# Altium schematic sheet STC3115_without_SensorHub-EvalBoard.schdoc
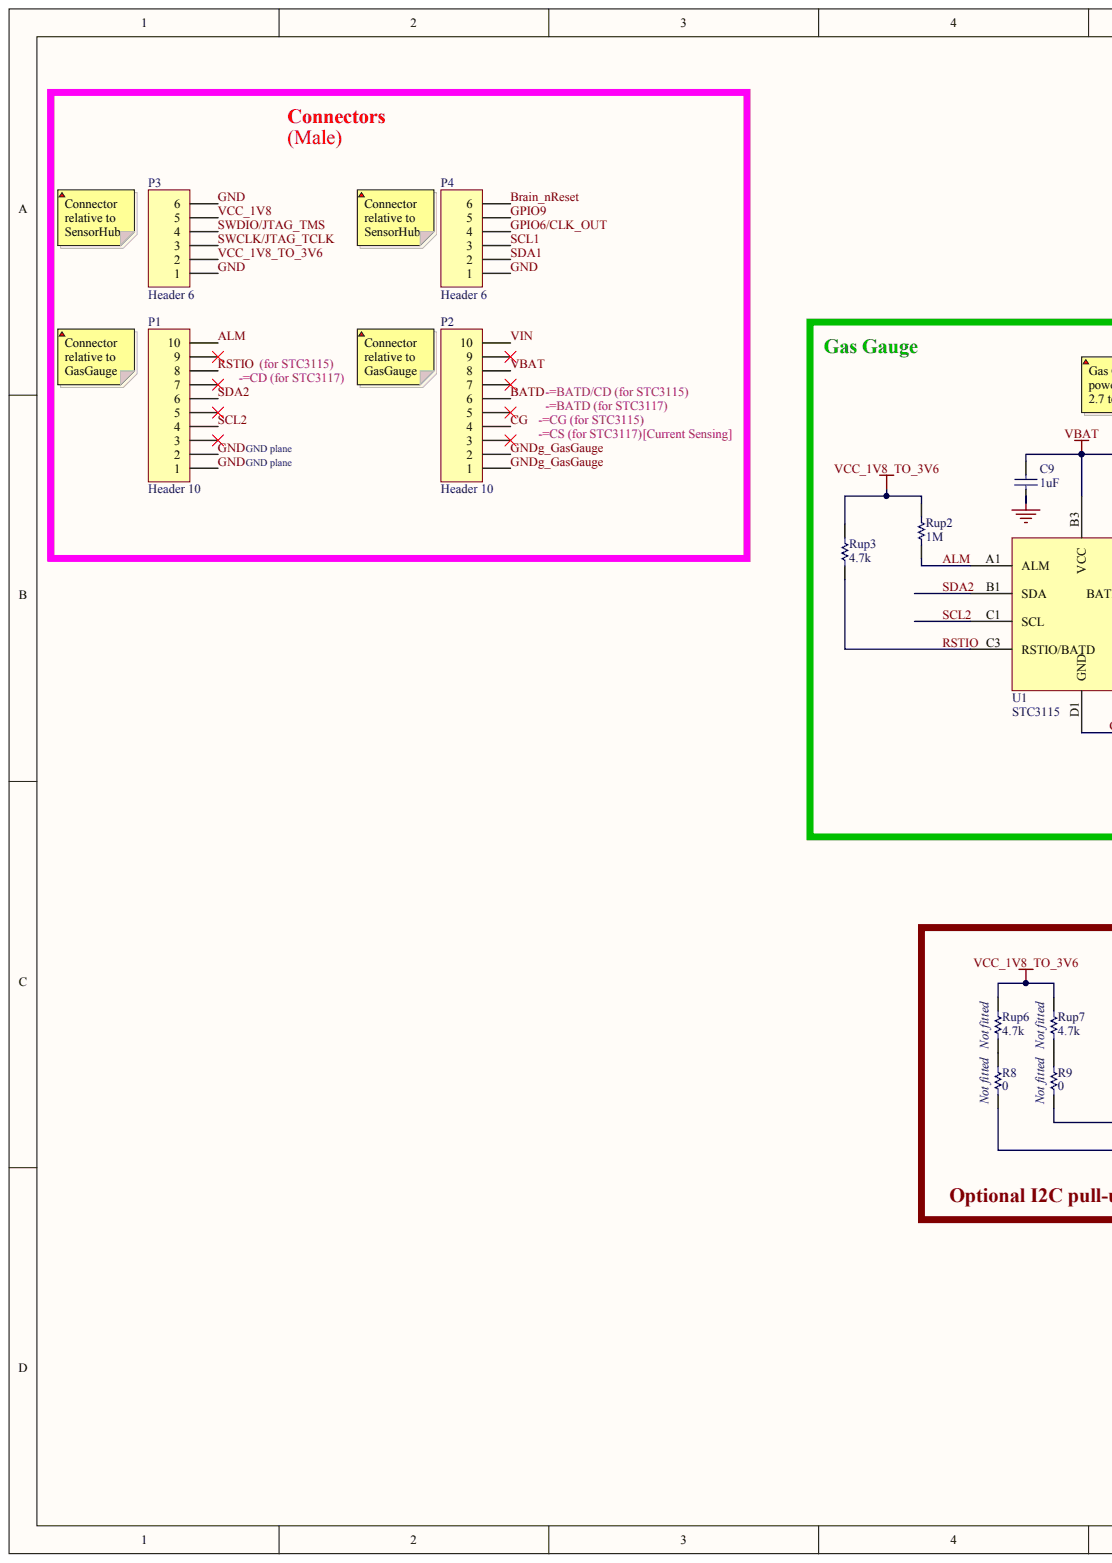
[diagram p0: STC3115_without_SensorHub-EvalBoard.schdoc, left]
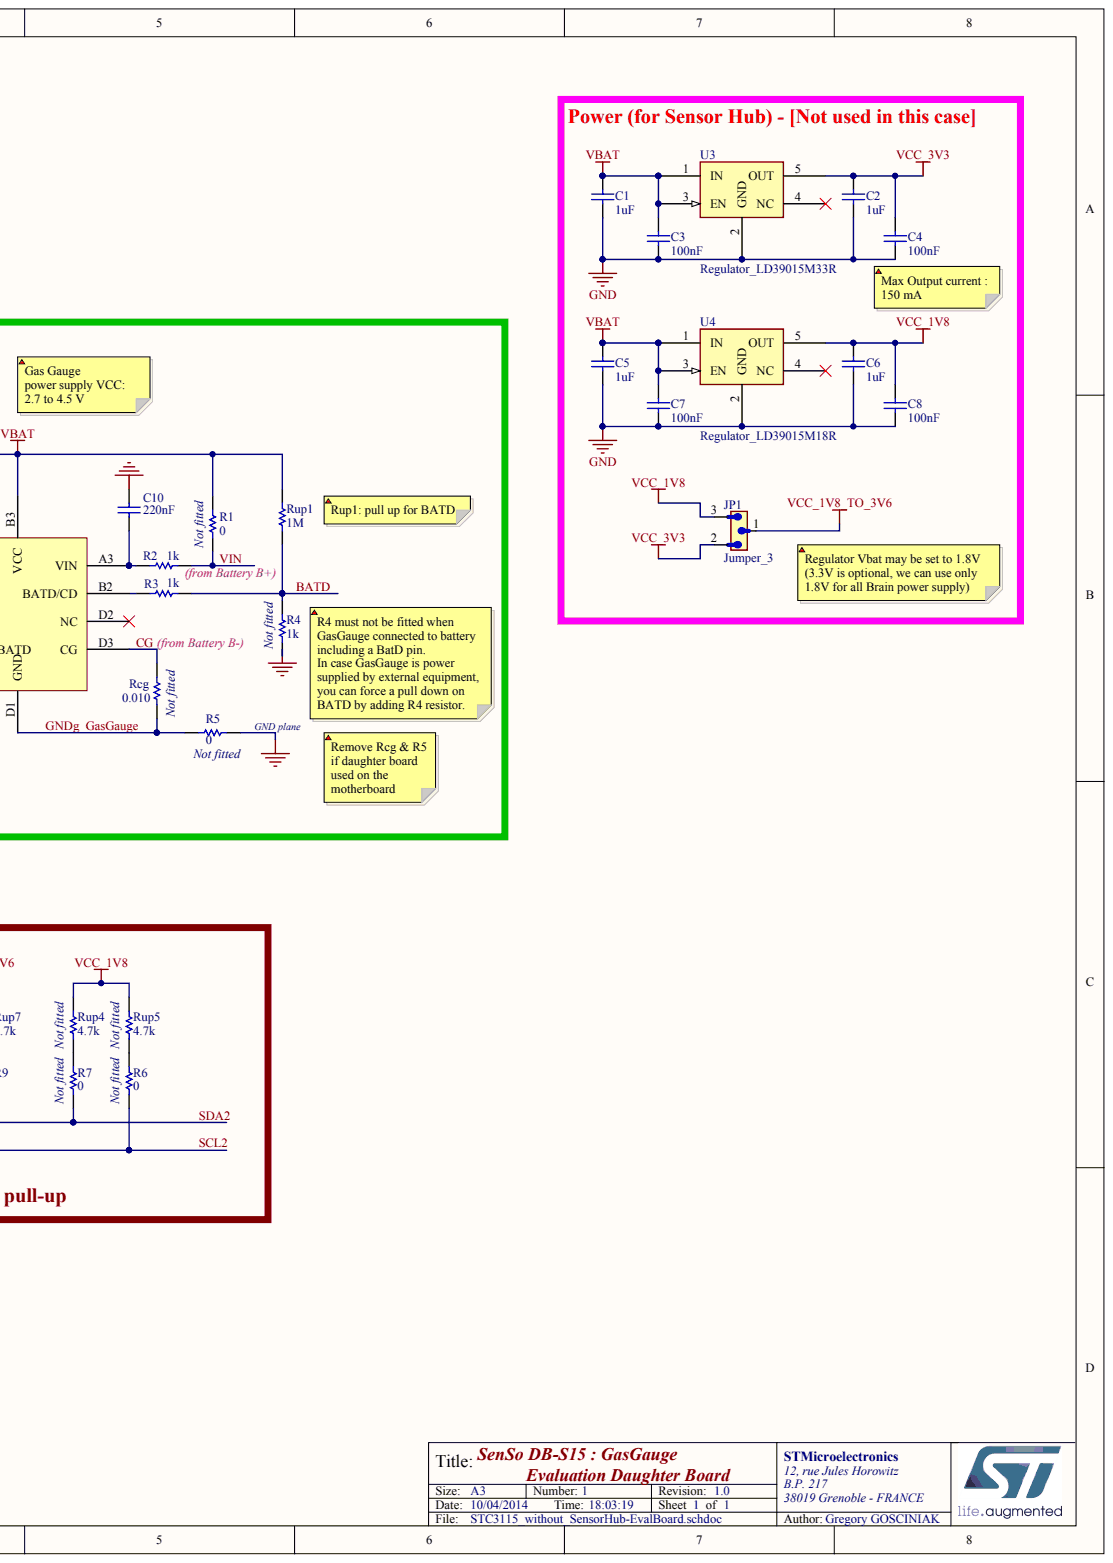
[diagram p1: STC3115_without_SensorHub-EvalBoard.schdoc, right]

## Parts
C1  1uF
C2  1uF
C3  100nF
C4  100nF
C5  1uF
C6  1uF
C7  100nF
C8  100nF
C9  1uF
C10  220nF
JP1  Jumper_3
P1  Header 10
P2  Header 10
P3  Header 6
P4  Header 6
R1  0
R2  1k
R3  1k
R4  1k
R5  0
R6  0
R7  0
R8  0
R9  0
Rcg  0.010
Rup1  1M
Rup2  1M
Rup3  4.7k
Rup4  4.7k
Rup5  4.7k
Rup6  4.7k
Rup7  4.7k
U1  STC3115
U3  Regulator_LD39015M33R
U4  Regulator_LD39015M18R

## Nets
VCC_3V3: C2.2 C4.2 JP1.2 U3.5(OUT)
ALM: P1.10 Rup2.2 U1.A1(ALM)
BATD: P2.6 R3.2 R4.2 Rup1.2
BRAIN_NRESET: P4.6
CG: P2.4 Rcg.1 U1.D3(CG)
GND: C1.1 C2.1 C3.1 C4.1 C5.1 C6.1 C7.1 C8.1 C9.1 C10.2 P1.1 P1.2 P3.1 P3.6 P4.1 R4.1 R5.1 U3.2(GND) U4.2(GND)
GNDG_GASGAUGE: P2.1 P2.2 R5.2 Rcg.2 U1.D1(GND)
GPIO6/CLK_OUT: P4.4
GPIO9: P4.5
RSTIO: P1.8 Rup3.2 U1.C3(RSTIO/BATD)
SCL1: P4.3
SCL2: P1.4 R6.1 R8.1 U1.C1(SCL)
SDA1: P4.2
SDA2: P1.6 R7.1 R9.1 U1.B1(SDA)
SWCLK/JTAG_TCLK: P3.3
SWDIO/JTAG_TMS: P3.4
VBAT: C1.2 C3.2 C5.2 C7.2 C9.2 P2.8 R1.1 Rup1.1 U1.B3(VCC) U3.1(IN) U3.3(EN) U4.1(IN) U4.3(EN)
VCC_1V8: C6.2 C8.2 JP1.3 P3.5 Rup4.1 Rup5.1 U4.5(OUT)
VCC_1V8_TO_3V6: JP1.1 P3.2 Rup2.1 Rup3.1 Rup6.1 Rup7.1
VIN: P2.10 R1.2 R2.1
NetC10_1: C10.1 R2.2 U1.A3(VIN)
NetR3_1: R3.1 U1.B2(BATD/CD)
NetR6_2: R6.2 Rup5.2
NetR7_2: R7.2 Rup4.2
NetR8_2: R8.2 Rup6.2
NetR9_2: R9.2 Rup7.2

Not connected: P1 3 5 7 9; P2 3 5 7 9; U1 D2(NC); U3 4(NC); U4 4(NC)

## Library data of the parts
R1-R9 Rcg Rup1-Rup7 = (no symbol name): Value: 10k; Published: 8-Jun-2000; LatestRevisionDate: 17-Jul-2002; LatestRevisionNote: Re-released for DXP Platform.; PackageReference: AXIAL-0.3; Publisher: Altium Limited
C1-C10 JP1 P1-P4 U1 U3 U4 = (no symbol name)
  C1 C2 C5 C6: Published: 8-Jun-2000; LatestRevisionDate: 17-Jul-2002; LatestRevisionNote: Re-released for DXP Platform.; PackageReference: RAD-0.3; PackageDescription: Radial Cap, Thru-Hole; 2 Leads; 0.3 in Pin Spacing; Value: 1uF
  C3 C4 C7 C8: Published: 8-Jun-2000; LatestRevisionDate: 17-Jul-2002; LatestRevisionNote: Re-released for DXP Platform.; PackageReference: RAD-0.3; PackageDescription: Radial Cap, Thru-Hole; 2 Leads; 0.3 in Pin Spacing; Value: 100nF
  C9: Published: 8-Jun-2000; LatestRevisionDate: 17-Jul-2002; LatestRevisionNote: Re-released for DXP Platform.; PackageReference: C1206; Value: 1uF
  C10: Published: 8-Jun-2000; LatestRevisionDate: 17-Jul-2002; LatestRevisionNote: Re-released for DXP Platform.; PackageReference: C1206; Value: 220nF
  P1-P4: LatestRevisionDate: 17-Jul-2002; LatestRevisionNote: Re-released for DXP Platform.
  U3 U4: Supplier 1: Farnell; Supplier Part Number 1: 2311333
  C1-C10 P1-P4: Publisher: Altium Limited
